# Altium schematic sheet Audio.SchDoc(Audio)
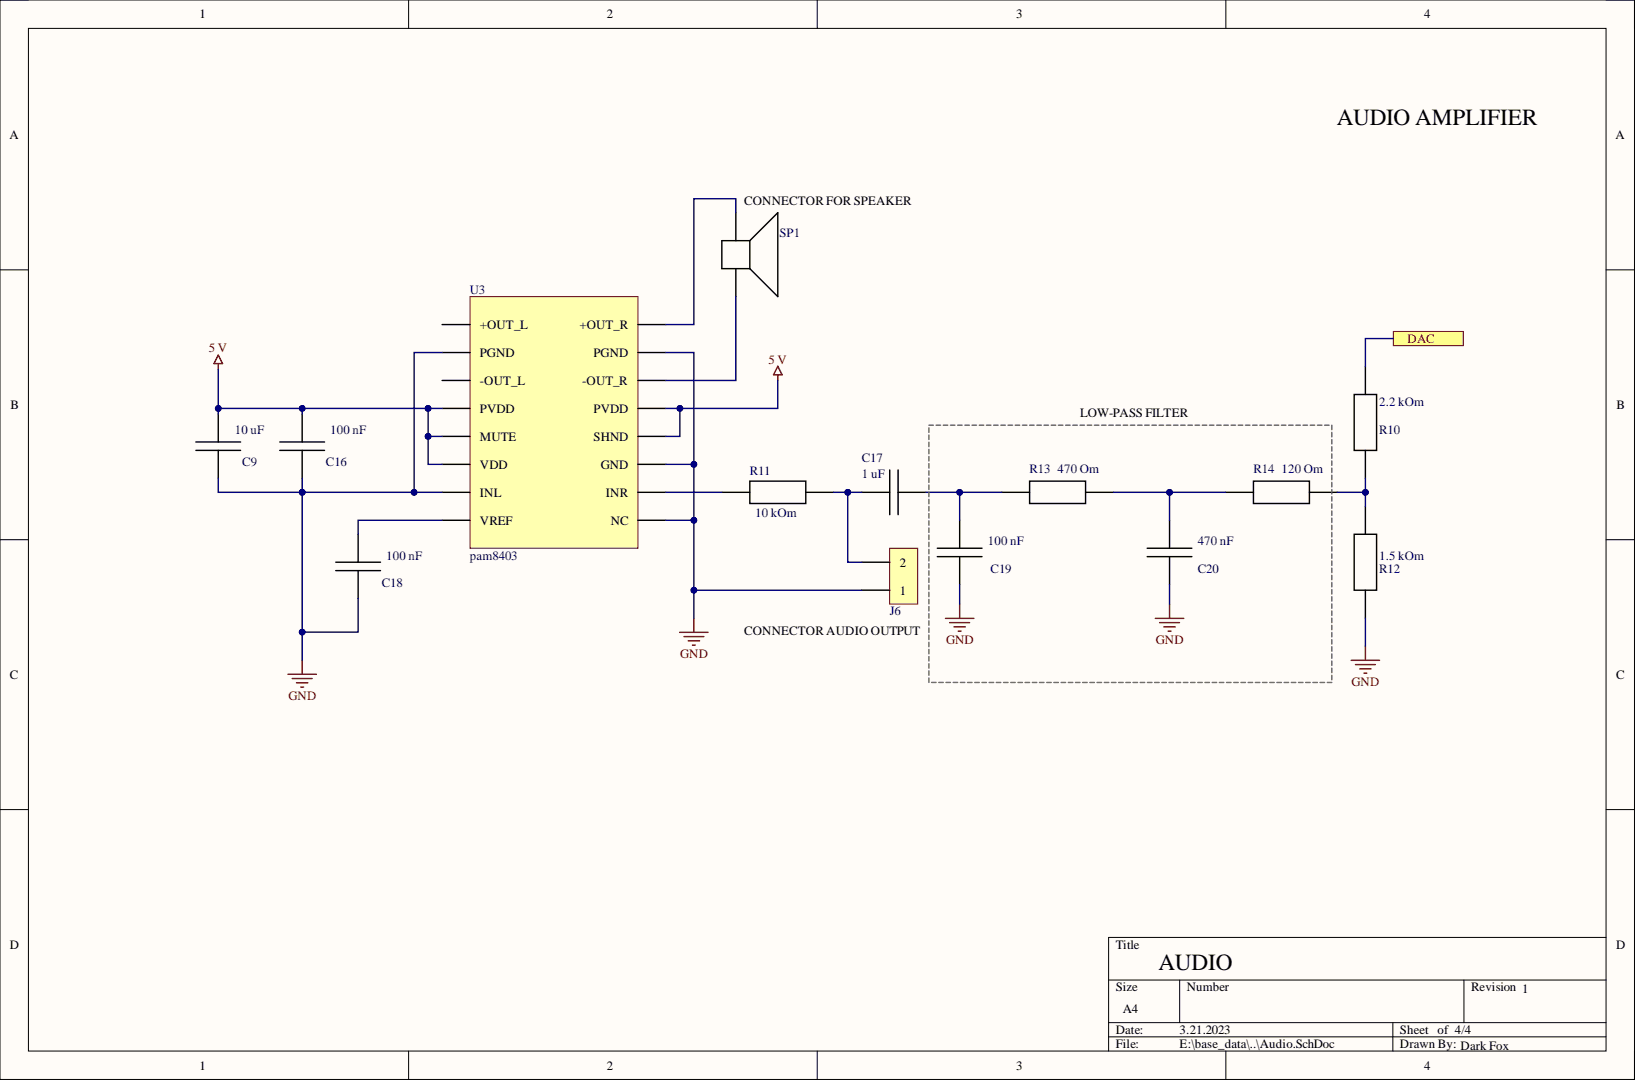
[diagram p0: Audio.SchDoc]

## Parts
C9  10 uF  [0805]
C16  100 nF  [0805]
C17  1 uF  [0805]
C18  100 nF  [0805]
C19  100 nF  [0805]
C20  470 nF  [0805]
J6  2x2.54  [SMD 2x2.54]  (value not drawn)
R10  2.2 kOm  [1206]
R11  10 kOm  [0805]
R12  1.5 kOm  [1206]
R13  470 Om  [1206]
R14  120 Om  [1206]
SP1  speaker  [SMD 2x2.54]  (value not drawn)
U3  pam8403  [sop16]

## Ports
DAC

## Nets
5 V: C9.2 C16.2 U3.4(PVDD) U3.5(MUTE) U3.6(VDD) U3.12(SHND) U3.13(PVDD)
GND: C9.1 C16.1 C18.1 C19.1 C20.1 J6.1 R12.1 U3.2(PGND) U3.7(INL) U3.9(NC) U3.11(GND) U3.15(PGND)
DAC: R10.2 ; port DAC
NetC17_1: C17.1 J6.2 R11.2
NetC17_2: C17.2 C19.2 R13.1
NetC18_2: C18.2 U3.8(VREF)
NetC20_2: C20.2 R13.2 R14.1
NetR10_1: R10.1 R12.2 R14.2
NetR11_1: R11.1 U3.10(INR)
NetSP1_1: SP1.1 U3.14(-OUT_R)
NetSP1_2: SP1.2 U3.16(+OUT_R)

Not connected: U3 1(+OUT_L) 3(-OUT_L)

## Library data of the parts
C9 C16-C20 = Cup: Component Kind: Standard; Library Name: MyLib.SchLib
R10-R14 = Res: Component Kind: Standard; Library Name: MyLib.SchLib
J6 = 2x2.54: Component Kind: Standard; Library Name: MyLib.SchLib
SP1 = speaker: Component Kind: Standard; Library Name: MyLib.SchLib
U3 = pam8403: Component Kind: Standard; Library Name: MyLib.SchLib
